# Altium schematic sheet Sheet1TV.SchDoc
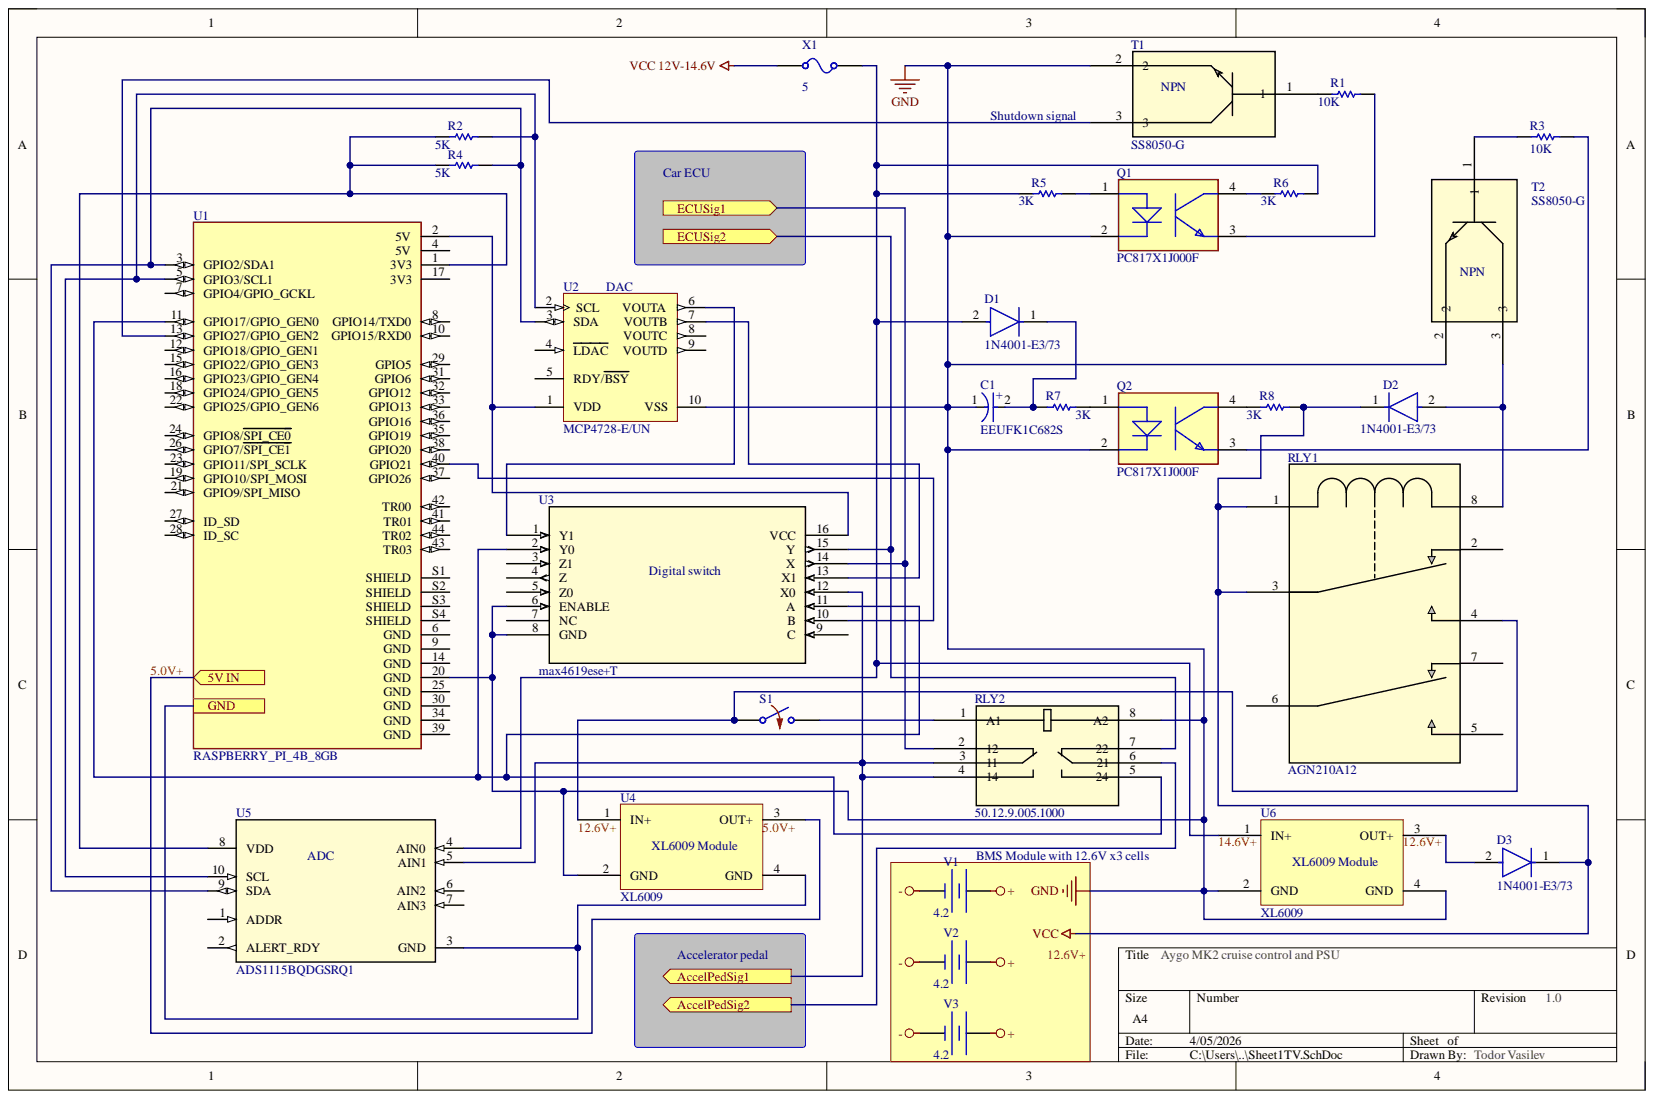
[diagram p0: Sheet1TV.SchDoc]

## Parts
C1  EEUFK1C682S  [FP-RAD-TH-D_18_0_5-L_25_2-MFG]  (mechanical)
D1  1N4001-E3/73  [FP-1N4001-E3-73-MFG]
D2  1N4001-E3/73  [FP-1N4001-E3-73-MFG]
D3  1N4001-E3/73  [FP-1N4001-E3-73-MFG]
Q1  PC817X1J000F  [FP-PC817X1J000F-MFG]
Q2  PC817X1J000F  [FP-PC817X1J000F-MFG]
R1  value 10K  (hidden comment: Resistor)
R2  value 5K  (hidden comment: Resistor)
R3  value 10K  (hidden comment: Resistor)
R4  value 5K  (hidden comment: Resistor)
R5  value 3K  (hidden comment: Resistor)
R6  value 3K  (hidden comment: Resistor)
R7  value 3K  (hidden comment: Resistor)
R8  value 3K  (hidden comment: Resistor)
RLY1  AGN210A12  [RELAY_AGN_A_PAN]
RLY2  50.12.9.005.1000  [RELAY8_50.12.9.005.1000_FIN]
S1  Switch Normally Opened  (value not drawn)
T1  SS8050-G  [TRAN_SS8050-G_CIP]
T2  SS8050-G  [TRAN_SS8050-G_CIP]
U1  RASPBERRY_PI_4B_8GB  [MODULE_RASPBERRY_PI_4B_4GB]
U2  MCP4728-E/UN  [MSOP-UN10_N]
U3  max4619ese+T  [21-0041_S16+1_MXM]
U4  XL6009
U5  ADS1115BQDGSRQ1  [DGS0010A_N]
U6  XL6009
V1  DC Magnitude: 4.2  (hidden comment: Constant Voltage Source)
V2  DC Magnitude: 4.2  (hidden comment: Constant Voltage Source)
V3  DC Magnitude: 4.2  (hidden comment: Constant Voltage Source)
X1  Fuse  (value not drawn)

## Ports
5V IN, AccelPedSig1, AccelPedSig2, ECUSig1, ECUSig2, GND

## Nets
+: V1.1 V2.1 V3.1
-: V1.2 V2.2 V3.2
GND: C1.1 Q1.2 Q2.2 RLY2.8(A2) T1.2 T2.2 U1.20(GND) U2.10(VSS) U3.6(ENABLE) U3.8(GND) U4.2(GND) U6.2(GND) U6.4(GND)
VCC: D2.1 D3.1 R8.2 RLY1.1 RLY1.3
VCC 12V-14.6V: X1.2
5V IN: U4.3(OUT+) ; port 5V IN
AccelPedSig1: RLY2.3 RLY2.4 U3.12(X0) U5.5(AIN1) ; port AccelPedSig1
AccelPedSig2: RLY2.6 ; port AccelPedSig2
ECUSig1: RLY2.2 U3.14(X) ; port ECUSig1
ECUSig2: RLY2.7 U3.15(Y) ; port ECUSig2
NetC1_2: C1.2 D1.1 R7.1
NetD1_2: D1.2 R5.1 R6.2 U5.4(AIN0) U6.1(IN+) X1.1
NetD2_2: D2.2 RLY1.8 T2.3
NetD3_2: D3.2 U6.3(OUT+)
NetQ1_1: Q1.1 R5.2
NetQ1_3: Q1.3 R1.2
NetQ1_4: Q1.4 R6.1
NetQ2_1: Q2.1 R7.2
NetQ2_3: Q2.3 R3.1
NetQ2_4: Q2.4 R8.1
NetR1_1: R1.1 T1.1
NetR2_1: R2.1 R4.1 U1.1(3V3) U5.8(VDD)
NetR2_2: R2.2 U1.5(GPIO3/SCL1) U2.2(SCL) U5.10(SCL)
NetR3_2: R3.2 T2.1
NetR4_2: R4.2 U1.3(GPIO2/SDA1) U2.3(SDA) U5.9(SDA)
NetRLY1_4: RLY1.4 S1.1 U4.1(IN+)
NetRLY2_1: RLY2.1(A1) S1.2
NetRLY2_5: RLY2.5 U1.11(GPIO17/GPIO_GEN0) U3.2(Y0) U3.11(A)
NetT1_3: T1.3 U1.13(GPIO27/GPIO_GEN2)
NetU1_2: U1.2(5V) U2.1(VDD) U3.16(VCC)
NetU1_40: U1.40(GPIO21) U3.10(B)
NetU2_6: U2.6(VOUTA) U3.1(Y1)
NetU2_7: U2.7(VOUTB) U3.13(X1)
NetU4_4: U4.4(GND) U5.3(GND) ; port GND

Not connected: RLY1 2 5 6 7; U1 4(5V) 6(GND) 7(GPIO4/GPIO_GCKL) 8(GPIO14/TXD0) 9(GND) 10(GPIO15/RXD0) 12(GPIO18/GPIO_GEN1) 14(GND) 15(GPIO22/GPIO_GEN3) 16(GPIO23/GPIO_GEN4) 17(3V3) 18(GPIO24/GPIO_GEN5) 19(GPIO10/SPI_MOSI) 21(GPIO9/SPI_MISO) 22(GPIO25/GPIO_GEN6) 23(GPIO11/SPI_SCLK) 24(GPIO8/SPI_CE0) 25(GND) 26(GPIO7/SPI_CE1) 27(ID_SD) 28(ID_SC) 29(GPIO5) 30(GND) 31(GPIO6) 32(GPIO12) 33(GPIO13) 34(GND) 35(GPIO19) 36(GPIO16) 37(GPIO26) 38(GPIO20) 39(GND) 41(TR01) 42(TR00) 43(TR03) 44(TR02) S1(SHIELD) S2(SHIELD) S3(SHIELD) S4(SHIELD); U2 4(LDAC) 5(RDY/BSY) 8(VOUTC) 9(VOUTD); U3 3(Z1) 4(Z) 5(Z0) 7(NC) 9(C); U5 1(ADDR) 2(ALERT_RDY) 6(AIN2) 7(AIN3)

## Library data of the parts
C1 = CMP-05427-000792-1: Aluminum Electrolytic Capacitors (Radial Lead Type) AEC-Q200 Qualified 4700uF ±20% 16V; Capacitance: 4.7mF; Dielectric Material: Aluminium; Impedance: 18mR; Lead Diameter: 0.8mm; Life (Hours): 5000Hour; Ripple Current: 2.85A; Case/Package: Radial; Construction: RADIAL; Diameter: 13mm; Height: 25mm; Lead Pitch: 7.5mm; Library Name: Altium Content Vault; Max Operating Temperature: 105°C; Min Operating Temperature: -55°C; Mount: Through Hole; Number of Pins: 2; Packaging: Bulk; Radiation Hardening: No; REACH SVHC: No SVHC; RoHS Compliant: Yes; Series: FK Radial; Termination: Radial; Tolerance: 20%; Voltage: 16V; Voltage Rating: 16V; Voltage Rating (DC): 16V
D1-D3 = CMP-02540-000001-1: DIODE GEN PURP 50V 1A DO204AL; Manufacturer: Vishay; Capacitance: 15pF; Max Junction Temp: +150°C; Max Surge Forward Current: 30A; Peak Reverse Current: 5uA; Value: 15pF; Automotive: No; Category: Diode; Component Kind: Standard; ComponentLink2Description: Datasheet; ComponentLink2URL: http://www.vishay.com/docs/88503/1n4001.pdf; Device Class L1: Discrete Semiconductors; Device Class L2: Diodes; Device Class L3: Rectifier Diodes; Digikey Description: DIODE GEN PURP 50V 1A DO204AL; Forward Current: 1A; Height: 2.7mm; Ipc Land Pattern Name: DIOPA1088W105L520D270; Lead Free: Yes; Library Name: Altium Content Vault; Manufacturer Part Number: 1N4001-E3/73; Max Repetitive Reverse Voltage: 50V; Mouser Description: Rectifiers Vr/50V Io/1A; Octopart: https://octopart.com/1n4001-e3%2F73-vishay-42330130; Package: 1N4001-E3/73; Reverse Voltage: 50V; RoHS: TRUE; Temperature: -50°C to +150°C; Temperature Range High: +150°C; Temperature Range Low: -50°C
Q1 Q2 = CMP-65434-000003-1: OPTOISOLATOR 5KV TRANS 4DIP; Collector Emitter Breakdown Voltage: 80V; Collector Emitter Saturation Voltage: 200mV; Current Transfer Ratio: 600%; Fall Time: 18000ns; Forward Current: 50mA; Forward Voltage: 1.4V; Input Current: 20mA; Max Power Dissipation: 200mW; Number of Channels: 1; Reverse Breakdown Voltage: 6V; Case/Package: DIP; Collector Emitter Voltage (VCEO): 80V; Component Kind: Standard; Isolation Voltage: 5kV; Library Name: Altium Content Vault; Max Collector Current: 50mA; Max Input Current: 50mA; Max Operating Temperature: 100°C; Min Operating Temperature: -30°C; Mount: Through Hole; Number of Elements: 1; Number of Pins: 4; Output Current per Channel: 50mA; Output Voltage: 80V; Package Quantity: 2000; Packaging: Sleeve; Power Dissipation: 200mW; Radiation Hardening: No; Rise Time: 18000ns
R1-R8 = Resistor: SimulationGenericComponent: True; TC1: 0; Component Kind: Standard; Description: Resistor; Library Name: Simulation Generic Components.IntLib; Simulation: R_Resistor_Default; TC2: 0
RLY1 = AGN210A12: Description; Manufacturer_Name: Panasonic; Component Kind: Standard; Library Name: AGN210A12.SchLib; Manufacturer_Part_Number: AGN210A12; RefDes: RefDes; Type: Type; Copyright: Copyright (C) 2025 Ultra Librarian. All rights reserved.
RLY2 = 50.12.9.005.1000: No Description Available; Manufacturer_Name: Finder; Component Kind: Standard; Library Name: 50P12P9P005P1000.SchLib; Manufacturer_Part_Number: 50.12.9.005.1000; RefDes: RefDes; Type: Type; Copyright: Copyright (C) 2026 Ultra Librarian. All rights reserved.
S1 = SW NO: closed: 0; Rclosed: 1m; Ropen: 1Meg; SimulationGenericComponent: True; Component Kind: Standard; Description: Switch Normally Opened; Library Name: Simulation Generic Components.IntLib; Simulation: SPST_SWITCH
T1 T2 = SS8050-G: Description; Manufacturer_Name: Comchip; Component Kind: Standard; Library Name: SS8050-G.SchLib; Manufacturer_Part_Number: SS8050-G; RefDes: RefDes; Type: Type; Copyright: Copyright (C) 2025 Ultra Librarian. All rights reserved.
U1 = RASPBERRY_PI_4B_4GB: BCM2711 Raspberry Pi 4 Model B 4GB - ARMÂ® CortexÂ®-A72 MPU Embedded Evaluation Board; MP: RASPBERRY PI 4B/4GB; Check_prices: https://www.snapeda.com/parts/RASPBERRY%20PI%204B/4GB/Raspberry+Pi/view-part/?ref=eda; Component Kind: Standard; Library Name: RASPBERRY_PI_4B_4GB.IntLib; MF: Raspberry Pi; Package: None; SnapEDA_Link: https://www.snapeda.com/parts/RASPBERRY%20PI%204B/4GB/Raspberry+Pi/view-part/?ref=snap
U2 = CMP-0188-01008-2: 12-Bit Quad Digital-to-Analog Converter with EEPROM Memory, 10-pin MSOP, Extended Temperature; Component Kind: Standard; ComponentLink1Description: Manufacturer URL; ComponentLink1URL: http://www.microchip.com/; ComponentLink2Description: Datasheet; ComponentLink2URL: http://ww1.microchip.com/downloads/en/DeviceDoc/22187E.pdf; ComponentLink3Description: Package Specification; ComponentLink3URL: http://www.microchip.com/stellent/groups/techpub_sg/documents/packagingspec/en012702.pdf; DatasheetVersion: revE, Oct-2010; Library Name: Altium Content Vault; PackageDescription: 10-Lead Plastic Micro Small Outline Package (UN) [MSOP]; PackageReference: MSOP-UN10; PackageVersion: revBB, Aug-2009; RevisionNote: URLs added. DatasheetVersion updated.
U3 = max4619ese+T: High-Speed, Low-Voltage, CMOS Analog Multiplexers/Switches; Manufacturer_Name: Analog Devices Inc; Component Kind: Standard; Library Name: max4619ese&plus_T.SchLib; Manufacturer_Part_Number: max4619ese+T; RefDes: RefDes; Type: Type; Copyright: Copyright (C) 2026 Ultra Librarian. All rights reserved.
U4 U6 = XL6009: XL6009 Module DC to DC converter; Component Kind: Standard; Library Name: XL6009Module.SchLib
U5 = ADS1115BQDGSRQ1: Automotive 16-bit, 860-SPS, 4-ch, delta-sigma ADC with PGA, oscillator, VREF, comparator and I2C 10-VSSOP -40 to 125; Manufacturer: Texas Instruments; PartNumber: ADS1115QDGSRQ1; CompType: IC; Component Kind: Standard; Library Name: ADS1115BQDGSRQ1.SchLib; Manufacturer_Name: Texas Instruments; Manufacturer_Part_Number: ADS1115BQDGSRQ1; MountType: SMT; PackageReference: DGS0010A; RefDes: RefDes; RoHS: Y; TYPE: TYPE; Copyright: Copyright (C) 2025 Ultra Librarian. All rights reserved.
V1-V3 = Battery: SimulationGenericComponent: True; Component Kind: Standard; Description: Constant Voltage Source; Library Name: Simulation Generic Components.IntLib; Simulation: Vconst
X1 = Fuse: CURRENT: 5; RESISTANCE: 1m; SimulationGenericComponent: True; Component Kind: Standard; Description: Fuse; Library Name: Simulation Generic Components.IntLib; Simulation: FUSE
